# Altium schematic sheet AudioPreAmp.SchDoc
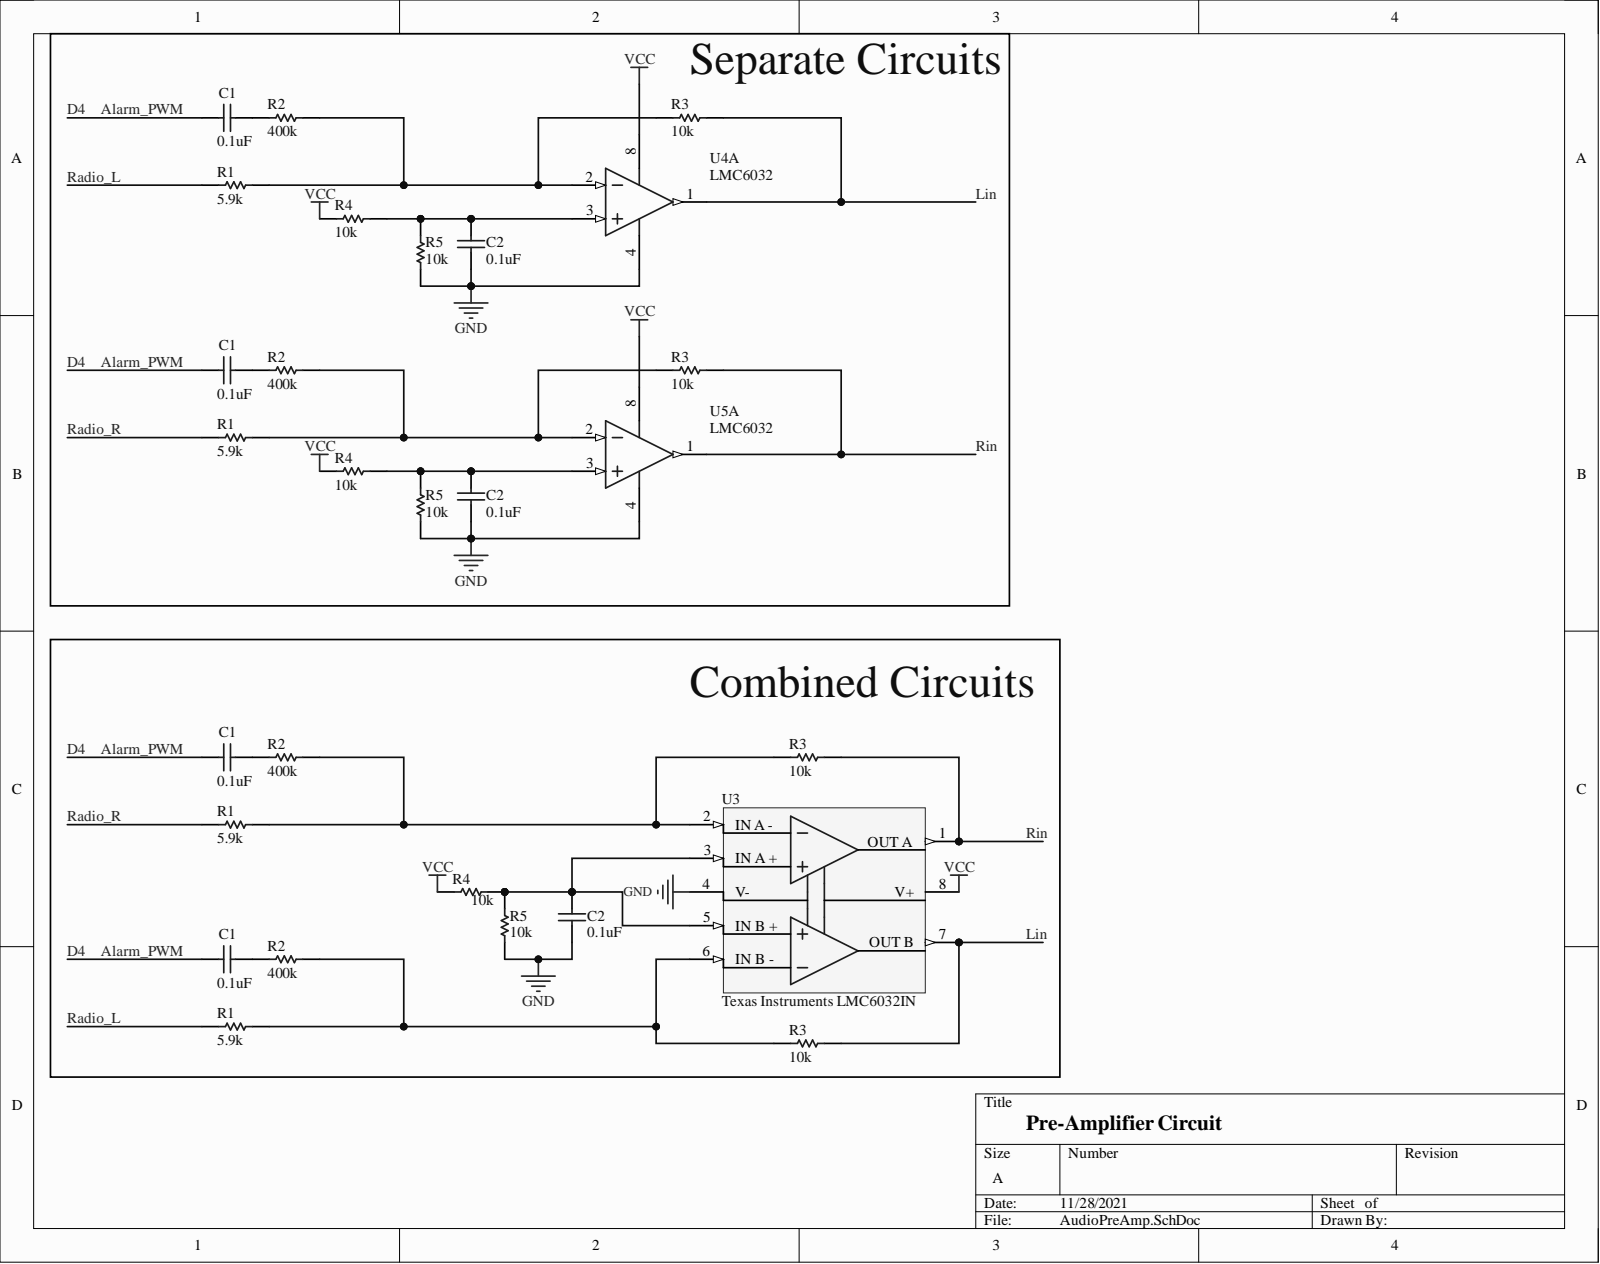
[diagram p0: AudioPreAmp.SchDoc]

## Parts
C1  0.1uF  [PCB-414doup3lqi1tfwm7xsm-1]
C1  0.1uF  [PCB-414doup3lqi1tfwm7xsm-1]
C1  0.1uF  [PCB-414doup3lqi1tfwm7xsm-1]
C1  0.1uF  [PCB-414doup3lqi1tfwm7xsm-1]
C2  0.1uF  [PCB-414doup3lqi1tfwm7xsm-1]
C2  0.1uF  [PCB-414doup3lqi1tfwm7xsm-1]
C2  0.1uF  [PCB-414doup3lqi1tfwm7xsm-1]
R1  5.9k  [PCB-q466dezs08uszq8774n0-1]
R1  5.9k  [PCB-q466dezs08uszq8774n0-1]
R1  5.9k  [PCB-q466dezs08uszq8774n0-1]
R1  5.9k  [PCB-q466dezs08uszq8774n0-1]
R2  400k  [PCB-q466dezs08uszq8774n0-1]
R2  400k  [PCB-q466dezs08uszq8774n0-1]
R2  400k  [PCB-q466dezs08uszq8774n0-1]
R2  400k  [PCB-q466dezs08uszq8774n0-1]
R3  10k  [PCB-q466dezs08uszq8774n0-1]
R3  10k  [PCB-q466dezs08uszq8774n0-1]
R3  10k  [PCB-q466dezs08uszq8774n0-1]
R3  10k  [PCB-q466dezs08uszq8774n0-1]
R4  10k  [PCB-q466dezs08uszq8774n0-1]
R4  10k  [PCB-q466dezs08uszq8774n0-1]
R4  10k  [PCB-q466dezs08uszq8774n0-1]
R5  10k  [PCB-q466dezs08uszq8774n0-1]
R5  10k  [PCB-q466dezs08uszq8774n0-1]
R5  10k  [PCB-q466dezs08uszq8774n0-1]
U3  Texas Instruments LMC6032IN  Manufacturer Part Number: LMC6032IN
U4  LMC6032  [M08A_L]  (part A)
U5  LMC6032  [M08A_L]  (part A)

## Nets
GND: C2.2 R5.1 U3.4(V-) U4.4 U5.4
VCC: R4.1 U3.8(V+) U4.8 U5.8
Alarm_PWM: C1.2
Lin: R3.2 U3.7(OUT_B) U4.1
Radio_L: R1.1
Radio_R: R1.1
Rin: R3.2 U3.1(OUT_A) U5.1
NetC1_1: C1.1 R2.1
NetC2_1: C2.1 R4.2 R5.2 U3.3(IN_A_+) U3.5(IN_B_+) U4.3 U5.3
NetR1_2: R1.2 R2.2 R3.1 U3.2(IN_A_-) U3.6(IN_B_-) U4.2 U5.2

## Library data of the parts
C1 C1 C1 C1 C2 C2 C2 = CMP-a01d69675d8a7fda-13: Cap Ceramic 0.1uF 50V X7R 10% Radial 2.54mm 125°C Bulk; Component Kind: Standard; Library Name: My Personal Space
R1 R1 R1 R1 R2 R2 R2 R2 R3 R3 R3 R3 R4 R4 R4 R5 R5 R5 = CMP-e82f23a0358237e8-1: RC Series 0201 0.05 W 1 kOhms 5% ±200 ppm/°C SMT Thick Film Chip Resistor; Manufacturer Part Number: RC0201JR-071KL; Lead-Free Status: Lead Free; Power Rating: 50.0 mW; Resistance: 1.00 kΩ; Voltage Rating (DC): 25.0 V; Case/Package: 0201; Component Kind: Standard; Library Name: My Personal Space; Mounting Style: Surface Mount; Number of Pins: 2; Octopart URL: http://octopart.com/rc0201jr-071kl-yageo-42334411; OctopartID: e82f23a0358237e8; Packaging: Tape & Reel; REACH SVHC Compliance: No SVHC; Resistance Tolerance: ±5%; RoHS: Compliant; Size-Length: 600 µm
U3 = CMP-4065896931359fa2-1: CMOS Dual Operational Amplifier 8-PDIP -40 to 85; Bandwidth: 1.40 MHz; Input Offset Drift: 2.30 µV/K; Lead-Free Status: Contains Lead; Number of Channels: 2; Output Current: 40.0 mA; Slew Rate: 1.10 V/μs; Case/Package: SOIC, PDIP; Component Kind: Standard; Gain Bandwidth Product: 1.40 MHz; Library Name: My Personal Space; Lifecycle Status: Active; Mounting Style: Through Hole; Number of Pins: 8; Octopart URL: http://octopart.com/lmc6032in-texas+instruments-414774; OctopartID: 4065896931359fa2; Packaging: Rail; RoHS: Non-Compliant
U4 U5 = CMP-0055-00692-3: CMOS Dual Operational Amplifier, 8-pin Narrow SOIC; Manufacturer Part Number: LMC6032IMX; Gain Bandwidth Product: 1400000.0 Hz; Input Offset Drift: 2.3e-06 V/K; Lead-Free Status: Contains Lead; Number of Channels: 2; Output Current: 0.04 A; Slew Rate: 1.1 V/μs; Component Kind: Standard; Library Name: My Personal Space; Lifecycle Status: Active; Number of Pins: 8; Octopart Url: https://octopart.com/lmc6032imx-texas+instruments-24849367; OctopartID: 8d1d456c83ac52da; Packaging: Reel; RoHS: Non-Compliant
